# Altium schematic sheet WatchDog.SchDoc
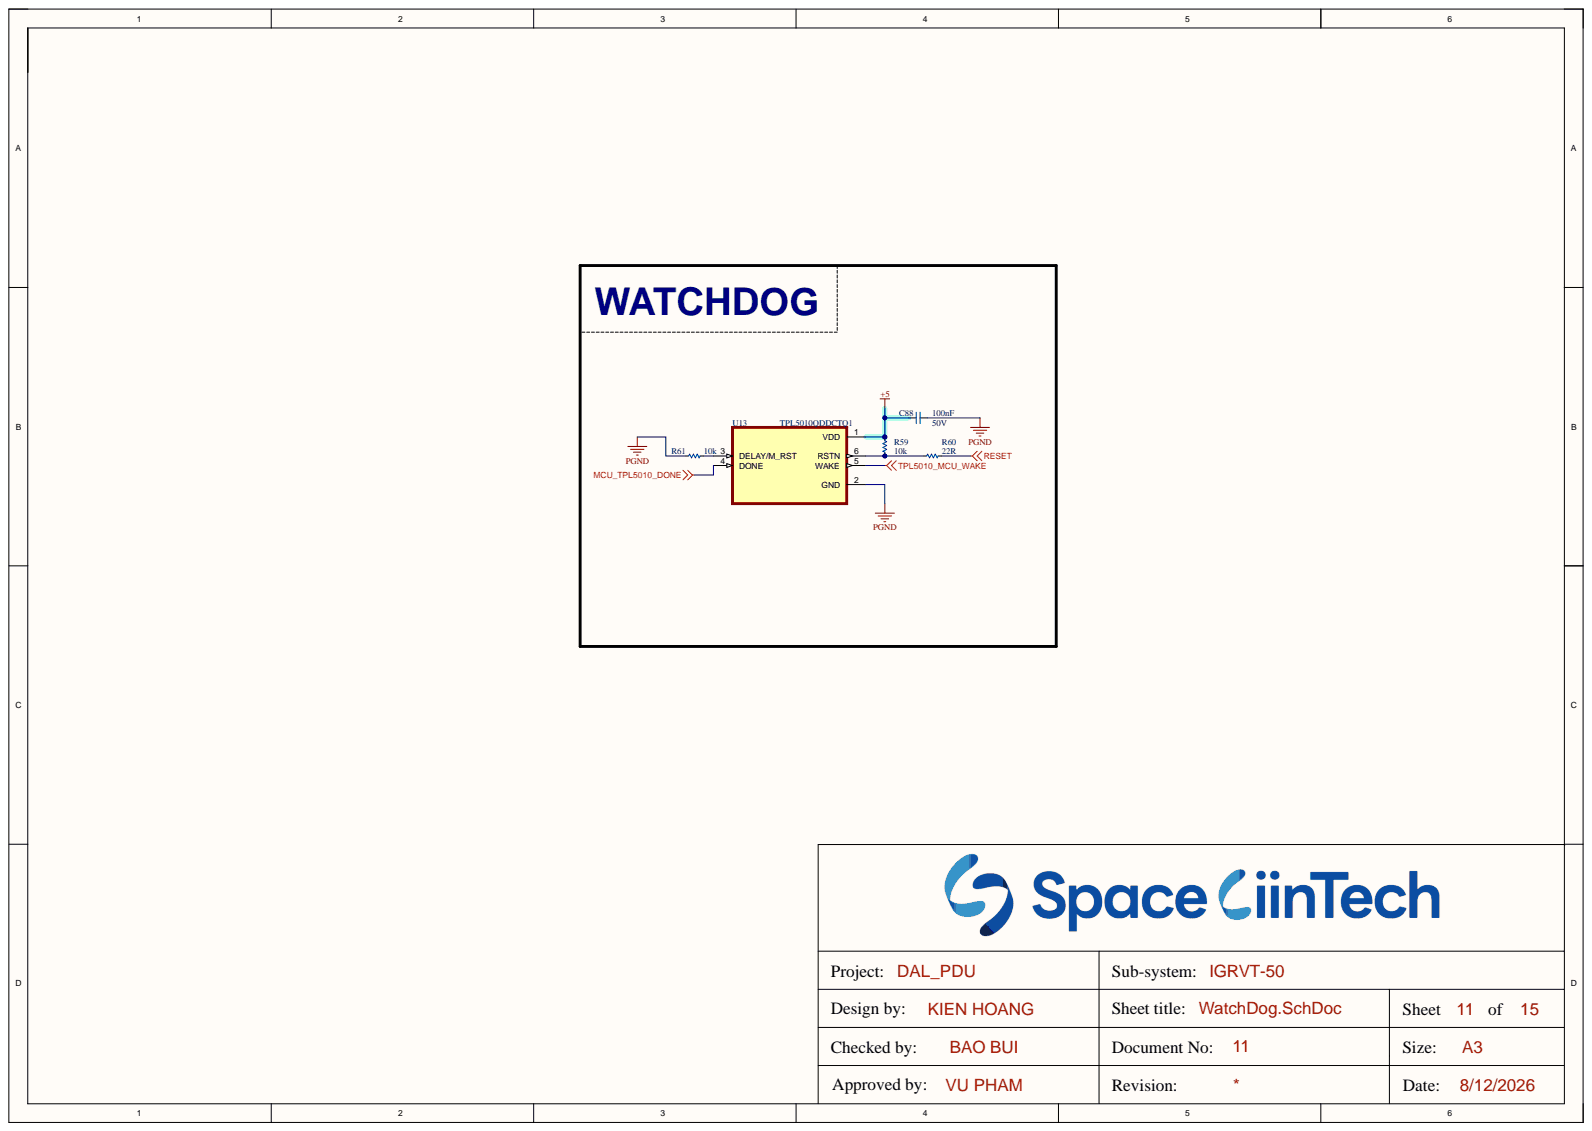
[diagram p0: WatchDog.SchDoc]

## Parts
C88  100nF  Voltage: 50V  [CAP_C_1608X80]
R59  10k  [RES_C_1608X60]
R60  22R  [RES_C_1608X60]
R61  10k  [RES_C_1608X60]
U13  TPL5010QDDCTQ1  [SOT-23-THN-6]

## Nets
+5: C88.2 R59.2 U13.1(VDD)
MCU_TPL5010_DONE: U13.4(DONE)
PGND: C88.1 R61.1 U13.2(GND)
RESET: R60.1
TPL5010_MCU_WAKE: U13.5(WAKE)
NetR59_1: R59.1 R60.2 U13.6(RSTN)
NetR61_2: R61.2 U13.3(DELAY/M_RST)

## Library data of the parts
C88 = _CAP_C_2: CAP; Component Kind: Standard; Library Name: LABLIB.SchLib
R59-R61 = _RES_B_2_2: RES; Component Kind: Standard; Library Name: LABLIB.SchLib
U13 = TPL5010QDDCTQ1: Component Kind: Standard; Library Name: KIENASIY123_LIB.IntLib
